# Altium schematic sheet actuators.SchDoc
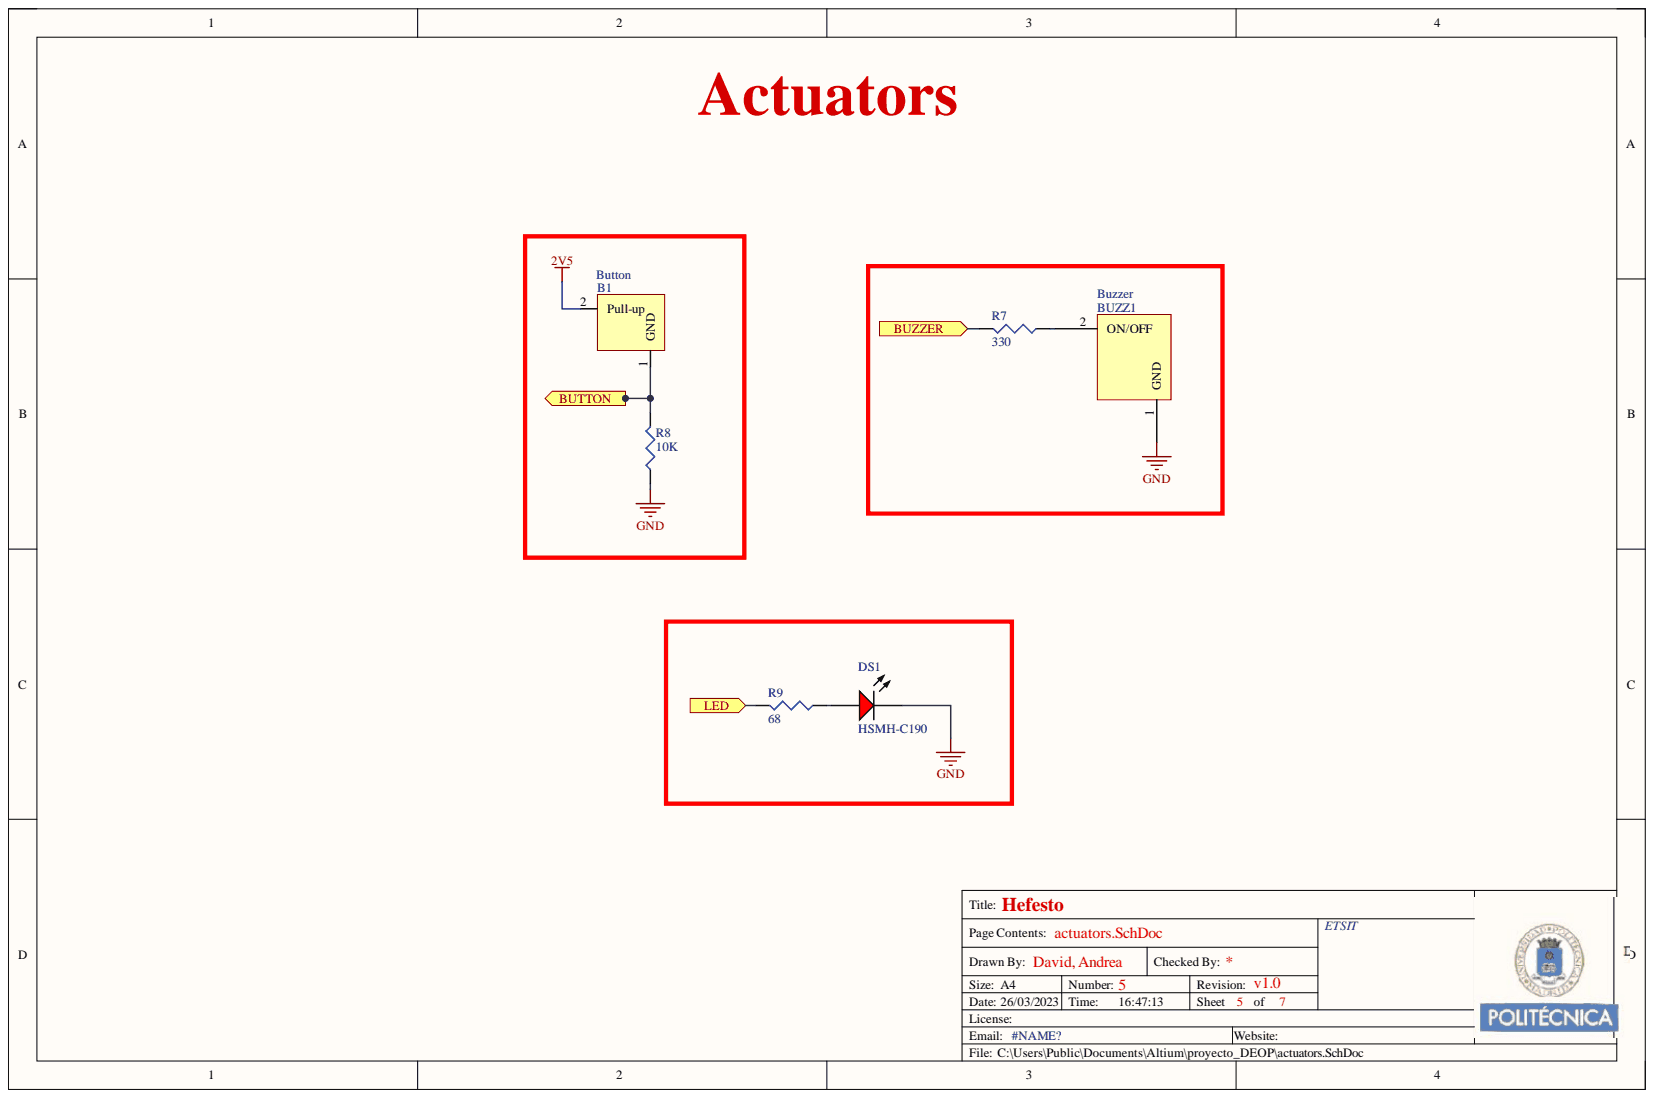
[diagram p0: actuators.SchDoc]

## Parts
B1  Button  [Button]
BUZZ1  Buzzer  [SOP_Buzzer]
DS1  HSMH-C190  [AVAG-HSMX-C190_V]
R7  value 330  [J1-0603]  (hidden comment: Res3)
R8  value 10K  [J1-0603]  (hidden comment: Res3)
R9  value 68  [J1-0603]  (hidden comment: Res3)

## Ports
BUTTON, BUZZER, LED

## Nets
2V5: B1.2
GND: BUZZ1.1 DS1.2 R8.1
BUTTON: R8.2 ; port BUTTON
BUZZER: R7.2 ; port BUZZER
LED: R9.2 ; port LED
NetB1_1: B1.1
NetBUZZ1_2: BUZZ1.2 R7.1
NetDS1_1: DS1.1 R9.1

## Library data of the parts
DS1 = CMP-1744-00004-1: LED Uni-Color Red, 65 mW, 20 mA, -40 to 85 degC, 2-Pin SMD, RoHS, Tape and Reel; Connector Type: USB; Current Rating: 1 A; Gender: Male; Component Kind: Standard; ComponentLink1Description: Manufacturer URL; ComponentLink1URL: http://www.avagotech.com/; ComponentLink2Description: Datasheet; ComponentLink2URL: http://docs.avagotech.com/docs/AV02-0551EN; ComponentLink3Description: Package Specification; ComponentLink3URL: http://www.fairchildsemi.com/dwg/MA/MA03D.pdf; Contact Material: Copper; Contact Plating: Gold; DatasheetVersion: Mar-12; Height: 2.8 mm; Lead Pitch: 0.65 mm; Length: 7.5 mm; Library Name: Components - 22.03.23 - 13.02.IntLib; Max Operating Temperature: 85 degC; Min Operating Temperature: -30 degC; Mount: Surface Mount; Package Quantity: 2000; PackageDescription: 2-Pin Surface Mount Device, Body 1.6 x 0.8 mm, Height 0.9 mm; PackageReference: HSMX-C190; Packaging: Tape and Reel; Pins: 5; REACH SVHC: No SVHC; RoHSCompliant: true; Width: 5.6 mm
R7-R9 = Res3: Resistor; Component Kind: Standard; LatestRevisionDate: 29-May-2009; LatestRevisionNote: IPC-7351 Footprint Added.; Library Name: Miscellaneous Devices.IntLib; PackageDescription: Chip Resistor; PackageReference: J1-0603; Published: 8-Jun-2000; Signal Integrity: Res; Simulation: RESISTOR; Publisher: Altium Limited
B1 = Button: Component Kind: Standard; Library Name: DEOP2023.IntLib
BUZZ1 = Buzzer: Component Kind: Standard; Library Name: DEOP2023.IntLib
